# Altium schematic sheet 05-Power.SchDoc(05-Power)
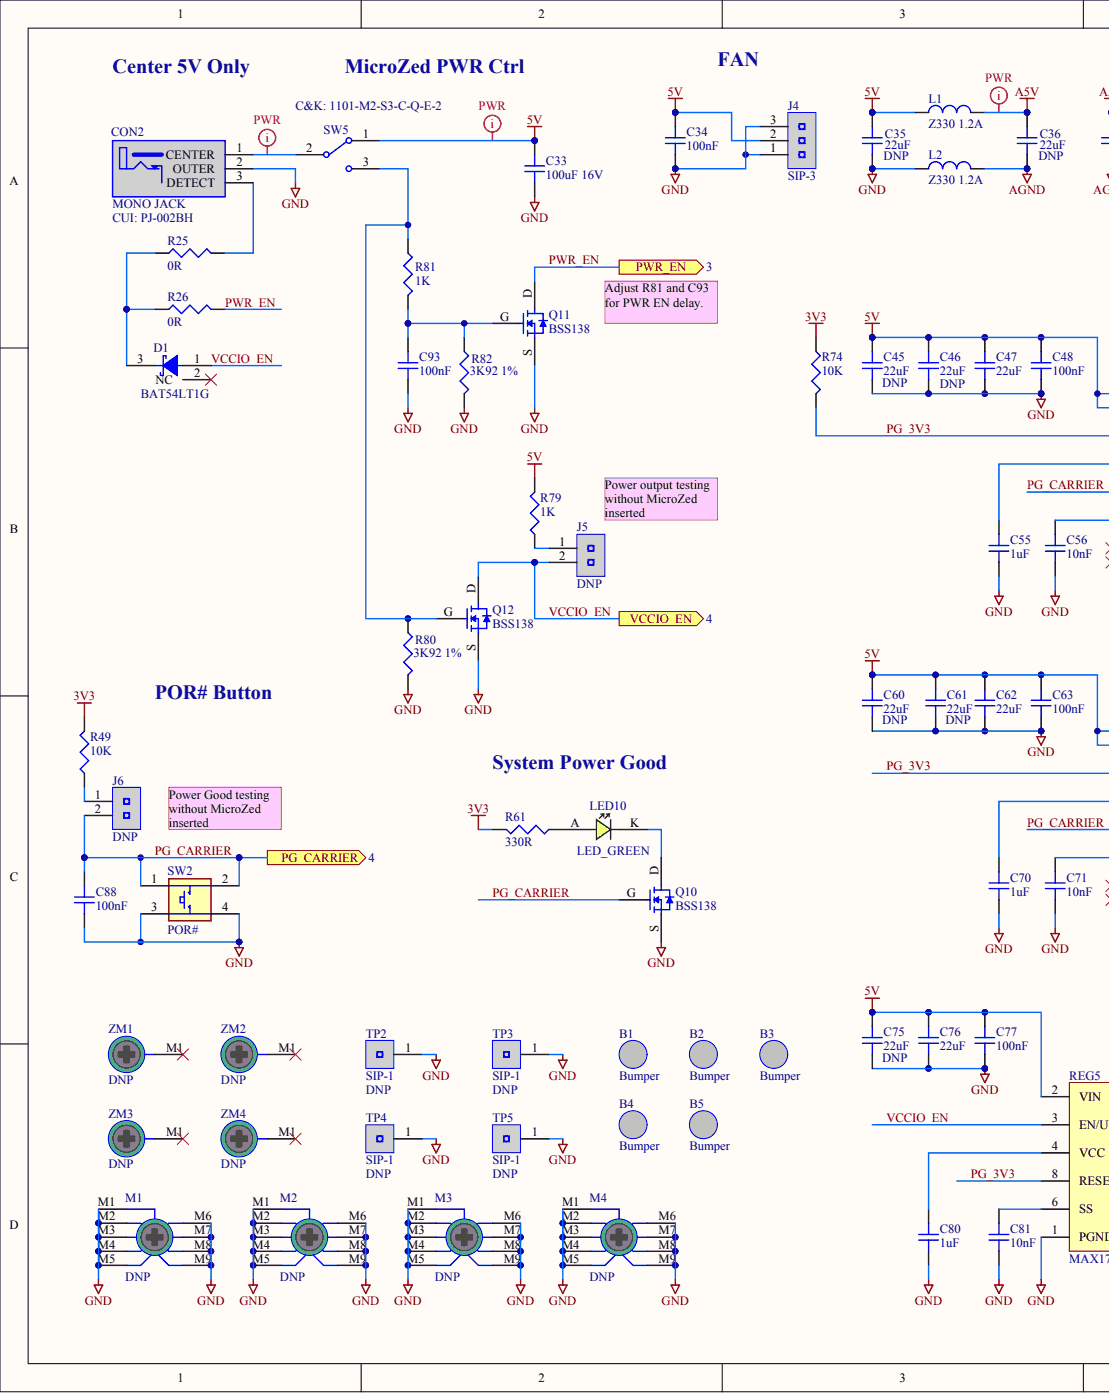
[diagram p0: 05-Power.SchDoc, left]
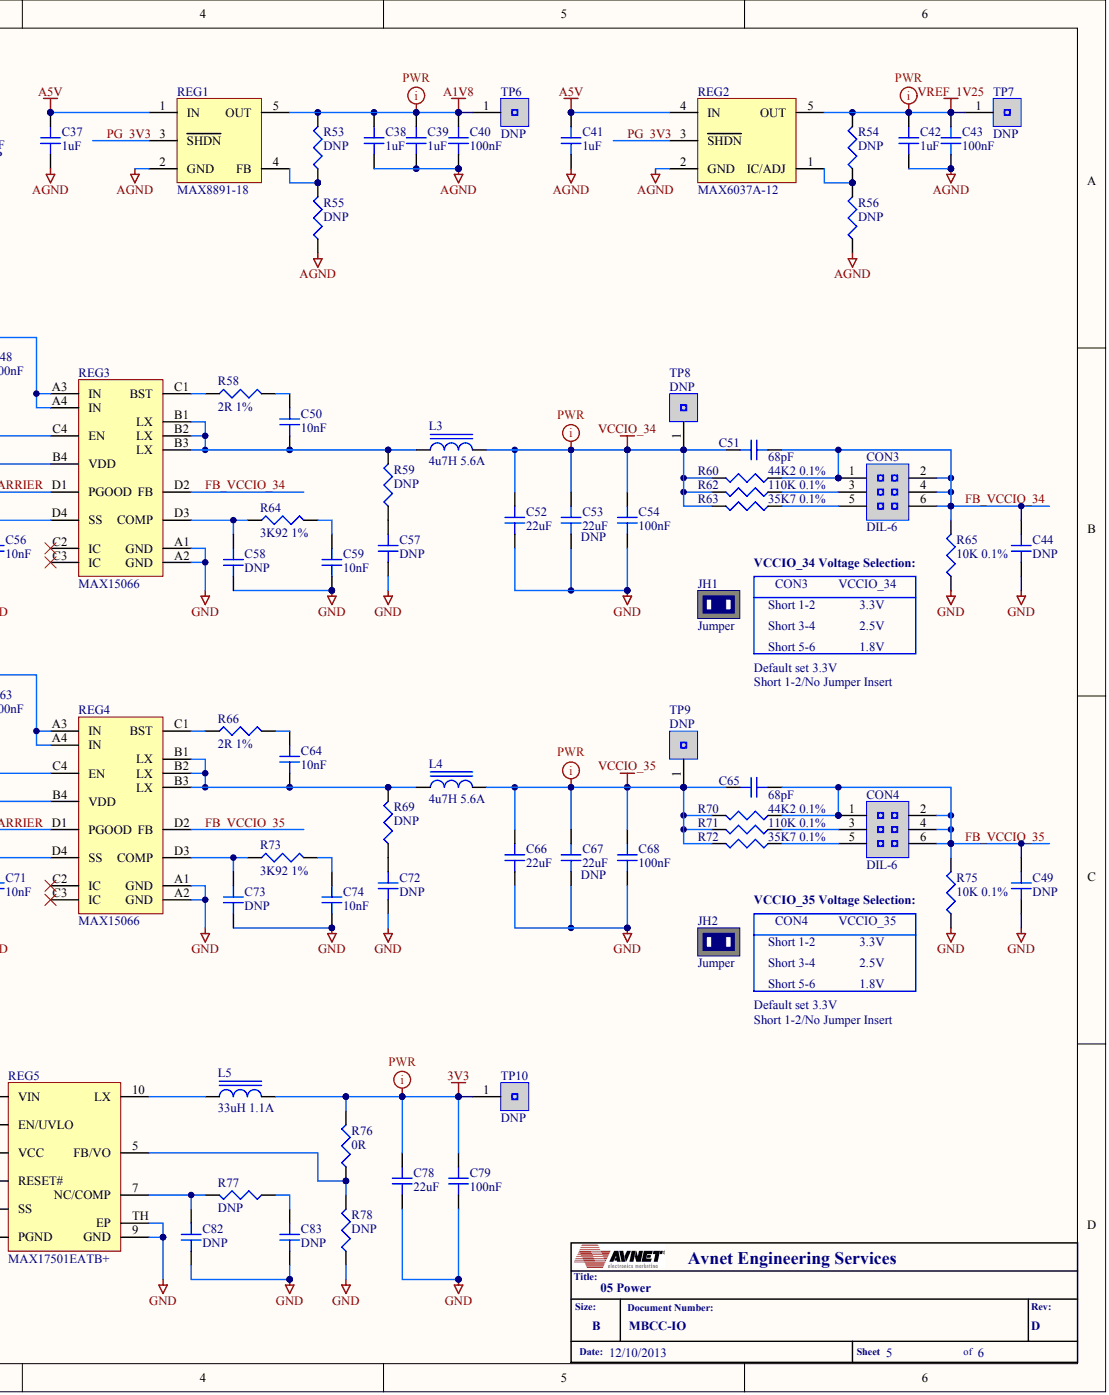
[diagram p1: 05-Power.SchDoc, right]

## Parts
B1  Bumper
B2  Bumper
B3  Bumper
B4  Bumper
B5  Bumper
C33  100uF 16V
C34  100nF
C35  22uF
C36  22uF
C37  1uF
C38  1uF
C39  1uF
C40  100nF
C41  1uF
C42  1uF
C43  100nF
C44  DNP
C45  22uF
C46  22uF
C47  22uF
C48  100nF
C49  DNP
C50  10nF
C51  68pF
C52  22uF
C53  22uF
C54  100nF
C55  1uF
C56  10nF
C57  DNP
C58  DNP
C59  10nF
C60  22uF
C61  22uF
C62  22uF
C63  100nF
C64  10nF
C65  68pF
C66  22uF
C67  22uF
C68  100nF
C70  1uF
C71  10nF
C72  DNP
C73  DNP
C74  10nF
C75  22uF
C76  22uF
C77  100nF
C78  22uF
C79  100nF
C80  1uF
C81  10nF
C82  DNP
C83  DNP
C88  100nF
C93  100nF
CON2  MONO JACK
CON3  DIL-6
CON4  DIL-6
D1  BAT54LT1G
J4  SIP-3
J5  DNP
J6  DNP
JH1  Jumper
JH2  Jumper
L1  Z330 1.2A
L2  Z330 1.2A
L3  4u7H 5.6A
L4  4u7H 5.6A
L5  33uH 1.1A
LED10  LED_GREEN
M1  DNP
M2  DNP
M3  DNP
M4  DNP
Q10  BSS138
Q11  BSS138
Q12  BSS138
R25  0R
R26  0R
R49  10K
R53  DNP
R54  DNP
R55  DNP
R56  DNP
R58  2R 1%
R59  DNP
R60  44K2 0.1%
R61  330R
R62  110K 0.1%
R63  35K7 0.1%
R64  3K92 1%
R65  10K 0.1%
R66  2R 1%
R69  DNP
R70  44K2 0.1%
R71  110K 0.1%
R72  35K7 0.1%
R73  3K92 1%
R74  10K
R75  10K 0.1%
R76  0R
R77  DNP
R78  DNP
R79  1K
R80  3K92 1%
R81  1K
R82  3K92 1%
REG1  MAX8891-18
REG2  MAX6037A-12
REG3  MAX15066
REG4  MAX15066
REG5  MAX17501EATB+
SW2  POR#
SW5  MFG#1/Part#: C&K: 1101-M2-S3-C-Q-E-2  (hidden comment: Switch Single Pole)
TP2  SIP-1
TP3  SIP-1
TP4  SIP-1
TP5  SIP-1
TP6  DNP
TP7  DNP
TP8  DNP
TP9  DNP
TP10  DNP
ZM1  DNP
ZM2  DNP
ZM3  DNP
ZM4  DNP

## Ports
PG_CARRIER, PWR_EN, VCCIO_EN

## Nets
3V3: C78.2 C79.2 L5.2 R49.2 R61.2 R74.1 R76.2 TP10.1
5V: C33.1 C34.1 C35.2 C45.2 C46.2 C47.2 C48.2 C60.2 C61.2 C62.2 C63.2 C75.2 C76.2 C77.2 J4.2 L1.1 R79.2 REG3.A3(IN) REG3.A4(IN) REG4.A3(IN) REG4.A4(IN) REG5.2(VIN) SW5.1
A1V8: C38.2 C39.2 C40.1 R53.2 REG1.5(OUT) TP6.1
A5V: C36.2 C37.2 C41.2 L1.2 REG1.1(IN) REG2.4(IN)
AGND: C36.1 C37.1 C38.1 C39.1 C40.2 C41.1 C42.1 C43.2 L2.2 R55.1 R56.1 REG1.2(GND) REG2.2(GND)
GND: C33.2 C34.2 C35.1 C44.1 C45.1 C46.1 C47.1 C48.1 C49.1 C52.1 C53.1 C54.1 C55.1 C56.1 C57.1 C58.1 C59.1 C60.1 C61.1 C62.1 C63.1 C66.1 C67.1 C68.1 C70.1 C71.1 C72.1 C73.1 C74.1 C75.1 C76.1 C77.1 C78.1 C79.1 C80.1 C81.1 C82.1 C83.1 C88.2 C93.2 CON2.2 J4.1 J4.3 L2.1 M1.M1 M1.M2 M1.M3 M1.M4 M1.M5 M1.M6 M1.M7 M1.M8 M1.M9 M2.M1 M2.M2 M2.M3 M2.M4 M2.M5 M2.M6 M2.M7 M2.M8 M2.M9 M3.M1 M3.M2 M3.M3 M3.M4 M3.M5 M3.M6 M3.M7 M3.M8 M3.M9 M4.M1 M4.M2 M4.M3 M4.M4 M4.M5 M4.M6 M4.M7 M4.M8 M4.M9 Q10.S Q11.S Q12.S R65.1 R75.1 R78.1 R80.2 R82.2 REG3.A1(GND) REG3.A2(GND) REG4.A1(GND) REG4.A2(GND) REG5.1(PGND) REG5.9(GND) REG5.TH(EP) SW2.3 SW2.4 TP2.1 TP3.1 TP4.1 TP5.1
VCCIO_34: C51.2 C52.2 C53.2 C54.2 L3.2 R60.2 R62.2 R63.2 TP8.1
VCCIO_35: C65.2 C66.2 C67.2 C68.2 L4.2 R70.2 R71.2 R72.2 TP9.1
VREF_1V25: C42.2 C43.1 R54.2 REG2.5(OUT) TP7.1
FB_VCCIO_34: C44.2 C51.1 CON3.1 CON3.2 CON3.4 CON3.6 R60.1 R65.2 REG3.D2(FB)
FB_VCCIO_35: C49.2 C65.1 CON4.1 CON4.2 CON4.4 CON4.6 R70.1 R75.2 REG4.D2(FB)
PG_3V3: R74.2 REG1.3(SHDN) REG2.3(SHDN) REG3.C4(EN) REG4.C4(EN) REG5.8(RESET#)
PG_CARRIER: C88.1 J6.2 Q10.G REG3.D1(PGOOD) REG4.D1(PGOOD) SW2.1 SW2.2 ; port PG_CARRIER
PWR_EN: Q11.D R26.2 ; port PWR_EN
VCCIO_EN: D1.1 J5.2 Q12.D REG5.3(EN/UVLO) ; port VCCIO_EN
NetC50_1: C50.1 L3.1 R59.2 REG3.B1(LX) REG3.B2(LX) REG3.B3(LX)
NetC50_2: C50.2 R58.2
NetC55_2: C55.2 REG3.B4(VDD)
NetC56_2: C56.2 REG3.D4(SS)
NetC57_2: C57.2 R59.1
NetC58_2: C58.2 R64.1 REG3.D3(COMP)
NetC59_2: C59.2 R64.2
NetC64_1: C64.1 L4.1 R69.2 REG4.B1(LX) REG4.B2(LX) REG4.B3(LX)
NetC64_2: C64.2 R66.2
NetC70_2: C70.2 REG4.B4(VDD)
NetC71_2: C71.2 REG4.D4(SS)
NetC72_2: C72.2 R69.1
NetC73_2: C73.2 R73.1 REG4.D3(COMP)
NetC74_2: C74.2 R73.2
NetC80_2: C80.2 REG5.4(VCC)
NetC81_2: C81.2 REG5.6(SS)
NetC82_2: C82.2 R77.2 REG5.7(NC/COMP)
NetC83_2: C83.2 R77.1
NetC93_1: C93.1 Q11.G R81.2 R82.1
NetCON2_1: CON2.1 SW5.2
NetCON2_3: CON2.3 R25.2
NetCON3_3: CON3.3 R62.1
NetCON3_5: CON3.5 R63.1
NetCON4_3: CON4.3 R71.1
NetCON4_5: CON4.5 R72.1
NetD1_3: D1.3 R25.1 R26.1
NetJ5_1: J5.1 R79.1
NetJ6_1: J6.1 R49.1
NetL5_1: L5.1 REG5.10(LX)
NetLED10_A: LED10.A R61.1
NetLED10_K: LED10.K Q10.D
NetQ12_G: Q12.G R80.1 R81.1 SW5.3
NetR53_1: R53.1 R55.2 REG1.4(FB)
NetR54_1: R54.1 R56.2 REG2.1(IC/ADJ)
NetR58_1: R58.1 REG3.C1(BST)
NetR66_1: R66.1 REG4.C1(BST)
NetR76_1: R76.1 R78.2 REG5.5(FB/VO)

Not connected: D1 2; REG3 C2(IC) C3(IC); REG4 C2(IC) C3(IC); ZM1 M1; ZM2 M1; ZM3 M1; ZM4 M1

## Library data of the parts
B1-B5 = (no symbol name): MFG#1/Part#: 3M: SJ5302
C33 = (no symbol name): MFG#1/Part#: TYC: EMK325ABJ107MM-T
C34 C40 C43 C48 C54 C63 C68 C77 C79 C88 C93 = (no symbol name): MFG#1/Part#: AVX:06035C104KAT2A
C35 C36 C45-C47 C52 C53 C60-C62 C66 C67 C75 C76 C78 = (no symbol name): MFG#1/Part#: AVX:1210YC226MAT2A
  C35 C36 C45 C46 C53 C60 C61 C67 C75: DNP: DNP
C37-C39 C41 C42 C55 C70 C80 = (no symbol name): MFG#1/Part#: AVX:0603YC105KAT2A
C44 C49 C57 C58 C72 C73 C82 C83 = (no symbol name): MFG#1/Part#: AVX:06035CxxxKAT2A; DNP: DNP
C50 C56 C59 C64 C71 C74 C81 = (no symbol name): MFG#1/Part#: AVX:06035C103KAT2A
C51 C65 = (no symbol name): MFG#1/Part#: AVX:06035A680FAT2A
CON2 = (no symbol name): MFG#B/Part#: Kycon: KLD-0202-A; MFG#A/Part#: Kycon: KLD-0202-B; MFG#C/Part#: CUI: PJ-002BH-SMT; MFG#1/Part#: CUI: PJ-002BH
CON3 CON4 = (no symbol name): MFG#1/Part#: TE: 5-146257-3
D1 = (no symbol name): MFG#1/Part#: OnSemi: BAT54LT1G
J4 = (no symbol name): MFG#B/Part#: Samtec: HTSW-103-08-G-S-RA; MFG#A/Part#: TE: 5-146280-3; MFG#1/Part#: Molex: 22272031
J5 J6 = (no symbol name): MFG#1/Part#: TE: 5-146280-2; MFG#B/Part#: Molex: 5267-2; DNP: DNP
JH1 JH2 = (no symbol name): MFG#1/Part#: 3M: 969102-0000-DA
L1 L2 = (no symbol name): MFG#1/Part#: muRata: BLM18PG331SN1
L3 L4 = (no symbol name): MFG#B/Part#: Bourns: SRR6038-xxxY; MFG#A/Part#: Bourns: SRU2009-xxxY; MFG#C/Part#: Sumida: CDRH12xxxxxx; MFG#D/Part#: Sumida: CDRH6Dxxxxx; MFG#G/Part#: Vishay: IDCP1813ERxxxM; MFG#F/Part#: Vishay: IDC7328ERxxxM; MFG#E/Part#: Sumida: CDRH10xxxxxxx; MFG#H/Part#: Sumida: CDRH4D22/HP; MFG#1/Part#: Bourns: SRR1240-4R7M
L5 = (no symbol name): MFG#1/Part#: Bourns: SRR6038-330Y; MFG#A/Part#: Bourns: SRU2009-xxxY; MFG#C/Part#: Sumida: CDRH12xxxxxx; MFG#D/Part#: Sumida: CDRH6Dxxxxx; MFG#G/Part#: Vishay: IDCP1813ERxxxM; MFG#F/Part#: Vishay: IDC7328ERxxxM; MFG#E/Part#: Sumida: CDRH10xxxxxxx; MFG#H/Part#: Sumida: CDRH4D22/HP; MFG#I/Part#: Bourns: SRR0603-xxxML
LED10 = (no symbol name): MFG#1/Part#: LTP: LTST-C190GKT
Q10-Q12 = (no symbol name): MFG#1/Part#: OnSemi: BSS138LT1G
R25 R26 R76 = (no symbol name): MFG#1/Part#: Vishay: CRCW06030000Z0EA
R49 R74 = (no symbol name): MFG#1/Part#: Vishay: CRCW060310K0JNEA
R53-R56 R59 R69 R77 R78 = (no symbol name): MFG#1/Part#: Vishay: CRCW0603xxxxJNEA; DNP: DNP
R58 R66 = (no symbol name): MFG#1/Part#: Vishay: CRCW06032R00FKEA
R60 R70 = (no symbol name): MFG#1/Part#: Vishay: TNPW060344K2BEEA
R61 = (no symbol name): MFG#1/Part#: Vishay: CRCW0603330RJNEA
R62 R71 = (no symbol name): MFG#1/Part#: Vishay: TNPW0603110KBEEA
R63 R72 = (no symbol name): MFG#1/Part#: Vishay: TNPW060335K7BEE
R64 R73 R80 R82 = (no symbol name): MFG#1/Part#: Vishay: CRCW06033K92FKEA
R65 R75 = (no symbol name): MFG#1/Part#: Vishay:  TNPW060310K0BEEA
R79 R81 = (no symbol name): MFG#1/Part#: Vishay: CRCW06031K00JNEA
REG1 = (no symbol name): MFG#1/Part#: MAXIM: MAX8891EXK18+T
REG2 = (no symbol name): MFG#1/Part#: MAXIM: MAX6037AAUK12+T
REG3 REG4 = (no symbol name): MFG#1/Part#: MAXIM: MAX15066EWE+
REG5 = (no symbol name): MFG#1/Part#: Maxim: MAX17501EATB+
SW2 = (no symbol name): MFG#1/Part#: TE: 1825910-7 (FSM4JAH); MFG#B/Part#: TE: 4-1437565-1 (FSM4JSMA)
TP2-TP10 = (no symbol name): MFG#1/Part#: TE: 5-146280-1; DNP: DNP
M1-M4 SW5 ZM1-ZM4 = (no symbol name)
  M1-M4 ZM1-ZM4: MFG#1/Part#: *; DNP: DNP
